# Altium schematic sheet schemat.SchDoc(schemat)
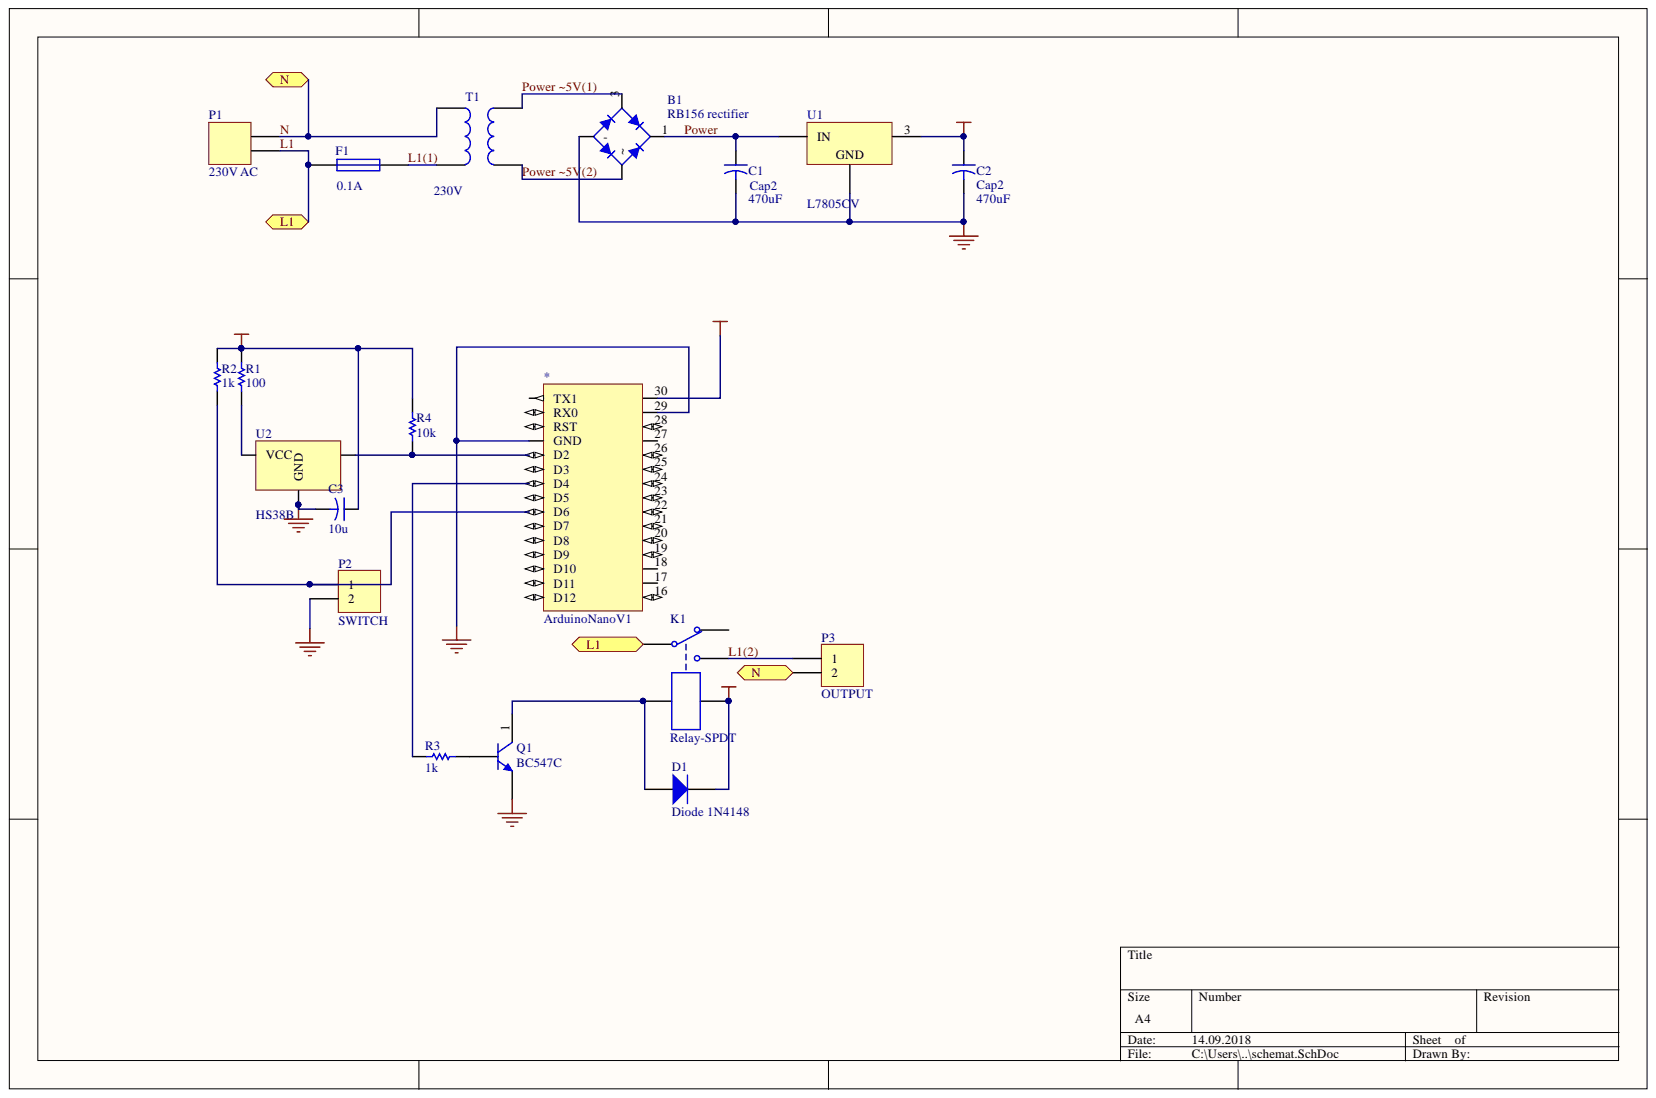
[diagram p0: schemat.SchDoc]

## Parts
*  ArduinoNanoV1  [DIP30]
B1  RB156 rectifier  [RB15]
C1  Cap2  value 470uF  [CAPR5-4X5]
C2  Cap2  value 470uF  [CAPR5-4X5]
C3  value 10u  [Capacitor]  (hidden comment: Cap2)
D1  Diode 1N4148  [DO-35]
F1  current: 0.1A  [PIN-W2/E2.8]  (hidden comment: Fuse 1)
K1  Relay-SPDT  [RELAY_OMRON_G5LE-1]
P1  230V AC  [ARK2]
P2  SWITCH  [ARK2]
P3  OUTPUT  [ARK2]
Q1  BC547C  [ONSC-TO-92-3-29-10_BL]
R1  value 100  [AXIAL-0.3]  (hidden comment: Res1)
R2  value 1k  [AXIAL-0.3]  (hidden comment: Res1)
R3  value 1k  [AXIAL-0.3]  (hidden comment: Res1)
R4  value 10k  [AXIAL-0.3]  (hidden comment: Res1)
T1  input voltage: 230V  [trafo HAHN 12V 3VA]  (hidden comment: Trans)
U1  L7805CV  [TO220]
U2  HS38B  [HS38B_3pin]

## Ports
L1, N

## Nets
GND: *.4(GND) *.29 B1.0(-) C1.2 C2.2 C3.2 P2.2 Q1.3 U1.2 U2.2
VCC: *.30 C2.1 C3.1 D1.2 K1.5 R1.2 R2.2 R4.2 U1.3
L1: F1.1 K1.1 P1.1 ; port L1
L1(1): F1.2 T1.2
L1(2): K1.3 P3.1
N: P1.2 P3.2 T1.1 ; port N
Power: B1.1 C1.1 U1.1
Power ~5V(1): B1.3 T1.3
Power ~5V(2): B1.2(~) T1.4
Net*_5: *.5(D2) R4.1 U2.3
Net*_7: *.7(D4) R3.2
Net*_9: *.9(D6) P2.1 R2.1
NetD1_1: D1.1 K1.4 Q1.1
NetQ1_2: Q1.2 R3.1
NetR1_1: R1.1 U2.1

Not connected: * 1(TX1) 2(RX0) 3(RST) 6(D3) 8(D5) 10(D7) 11(D8) 12(D9) 13(D10) 14(D11) 15(D12) 16 17 18 19 20 21 22 23 24 25 26 27 28; K1 2

## Library data of the parts
C1-C3 = Cap2: Capacitor; Simulation: CAP; Signal Integrity: Cap; Published: 23-Sep-2002; PackageReference: CAPR5-4X5; PackageDescription: Capacitor; 2 Leads; Library Name: Miscellaneous Devices.IntLib; Component Kind: Standard; Publisher: Altium Limited
D1 = Diode 1N4148: High Conductance Fast Diode; Simulation: 1N4148; Signal Integrity: 1N4148; Published: 8-Jun-2000; PackageReference: DO-35; PackageDescription: Diode, Axial; Body 3.8 x 1.9 mm (LxDia. max), Lead Dia. 0.53 mm (max); Library Name: Miscellaneous Devices.IntLib; LatestRevisionNote: Re-released for DXP Platform.; LatestRevisionDate: 17-Jul-2002; DatasheetVersion: 1997; ComponentLink2URL: http://www.fairchildsemi.com/ds/1N%2F1N4148.pdf; ComponentLink2Description: Datasheet; ComponentLink1URL: http://www.fairchildsemi.com/; ComponentLink1Description: Manufacturer Link; Component Kind: Standard; Code_JEDEC: DO-204-AH; Publisher: Altium Limited
F1 = Fuse 1: Fuse; Simulation: FUSE; Published: 8-Jun-2000; PackageReference: PIN-W2/E2.8; PackageDescription: Thru-Hole; 2 Leads; Library Name: Miscellaneous Devices.IntLib; LatestRevisionNote: Re-released for DXP Platform.; LatestRevisionDate: 17-Jul-2002; Component Kind: Standard; Publisher: Altium Limited
K1 = Relay-SPDT: Single-Pole Dual-Throw Relay; Simulation: SPDTRELAY; Published: 24-Mar-1999; PackageReference: MODULE5B; Library Name: Miscellaneous Devices.IntLib; LatestRevisionNote: Re-released for DXP Platform.; LatestRevisionDate: 17-Jul-2002; Component Kind: Standard; Publisher: Altium Limited
P1-P3 = Header 2: Header, 2-Pin; Signal Integrity: Connector; Library Name: Miscellaneous Connectors.IntLib; LatestRevisionNote: Re-released for DXP Platform.; LatestRevisionDate: 17-Jul-2002; Component Kind: Standard; Publisher: Altium Limited
Q1 = BC547C: Amplifier Transistor, NPN Silicon, 3-Pin TO-92, Bulk Box; Manufacturer: On Semiconductor; IC Continuous (A): 0.1; fT Min (MHz): 150; Supplier Part Number 1: BC547COS-ND; Supplier 1: Digi-Key; RoHS: FALSE; Polarity: NPN; PartNumber: BC547C; Packing: Bulk Box; PackageVersion: Rev. AM; PackageReference: TO-92-3-29-11_AM_SL; PackageDescription: 3-Pin Transistor Outline, Body 4.825 x 3.685 mm, Pitch 1.27 mm; Mounting Technology: Through Hole; Library Name: ON Semi General Purpose Transistors.IntLib; DatasheetVersion: Rev. 7; ComponentLink3URL: http://www.onsemi.cn/pub_link/Collateral/2N6426-D.PDF; ComponentLink3Description: Package Specification; ComponentLink2URL: http://www.onsemi.com/pub_link/Collateral/BC546-D.PDF; ComponentLink2Description: Datasheet; ComponentLink1URL: http://www.onsemi.com/; ComponentLink1Description: Manufacturer URL; Component Kind: Standard
R1-R4 = Res1: Resistor; Simulation: RESISTOR; Signal Integrity: Res; Published: 8-Jun-2000; PackageReference: AXIAL-0.3; PackageDescription: Axial Device, Thru-Hole; 2 Leads; 0.3 in Pin Spacing; Library Name: Miscellaneous Devices.IntLib; LatestRevisionNote: Re-released for DXP Platform.; LatestRevisionDate: 17-Jul-2002; Component Kind: Standard; Publisher: Altium Limited
T1 = Trans: Transformer; output voltage: 12V; Simulation: TRANSFORMER; Published: 24-Mar-1999; PackageReference: TRANS; PackageDescription: Transformer; 4 Leads; Library Name: Miscellaneous Devices.IntLib; LatestRevisionNote: Mech Layer 1 removed.; LatestRevisionDate: 08-Jul-2005; Component Kind: Standard; Publisher: Altium Limited
U1 = L7805CV: Positive Voltage Regulator, 5V, 3-Pin TO-220; PartNumber: L7805CV; PackageVersion: ver3.0; PackageReference: TO220; PackageDescription: TO, Thru-Hole, Vertical, Heatsink Mounted; 3 In-Line Leads; Pitch 2.54 mm; Library Name: STMicroelectronics Power Management Linear Regulator.IntLib; DatasheetVersion: Nov-2011; ComponentLink3URL: http://www.st.com/internet/com/TECHNICAL_RESOURCES/TECHNICAL_LITERATURE/PACKAGE_INFORMATION/CD00004649.pdf; ComponentLink3Description: Package Specification; ComponentLink2URL: http://www.st.com/internet/com/TECHNICAL_RESOURCES/TECHNICAL_LITERATURE/DATASHEET/CD00000444.pdf; ComponentLink2Description: Datasheet; ComponentLink1URL: http://www.st.com; ComponentLink1Description: Manufacturer URL; Component Kind: Standard
* = ArduinoNanoV1: Component Kind: Standard; Library Name: moje komponenty.SchLib
B1 = RB156 rectifier: Component Kind: Standard; Library Name: moje komponenty.SchLib
U2 = HS38B: Component Kind: Standard; Library Name: moje komponenty.SchLib
